# Altium schematic sheet H2O_Board.SchDoc(H2O_Board) (continued)

## Library data of the parts
*1 = CMP-019-00001-1: Three Terminal Voltage Reference, 1 Output, 2.5V, CMOS, PDSO5; Case/Package: SOT-23; Component Kind: Standard; Library Name: CUAUV - Cornell University
C5 C7 C9 C10 C22-C24 C26 C35-C40 C48 = CMP-001-00015-1: Ceramic Capacitor, Multilayer, Ceramic, 16V, 5% +Tol, 5% -Tol, X7R, 15% TC, 0.1uF, Surface Mount, 0603; Capacitor Type: Ceramic; Case/Package: 0603; Component Kind: Standard; Max Operating Temperature: 125°C; Min Operating Temperature: -55°C; Mounting Technology: Surface Mount; Pins: 2; RoHS Compliant: Yes; Library Name: CUAUV - Cornell University
C6 = CMP-001-00021-2: Ceramic Capacitor, Multilayer, Ceramic, 10V, 10% +Tol, 10% -Tol, X5R, 15% TC, 10uF, Surface Mount, 0603; Capacitor Type: Ceramic; Case/Package: 0603; Component Kind: Standard; Max Operating Temperature: 85°C; Min Operating Temperature: -55°C; Mounting Technology: Surface Mount; Pins: 2; RoHS Compliant: Yes; Library Name: CUAUV - Cornell University
C8 C31 C46 C50 C54 = CMP-001-00013-2: Ceramic Capacitor, Multilayer, Ceramic, 16V, 80% +Tol, 20% -Tol, Y5V, -82/+22% TC, 1uF, Surface Mount, 0603; Capacitor Type: Ceramic; Case/Package: 0603; Component Kind: Standard; Max Operating Temperature: 85°C; Min Operating Temperature: -30°C; Mounting Technology: Surface Mount; Pins: 2; RoHS Compliant: Yes; Library Name: CUAUV - Cornell University
C11 C12 C15 C16 = CMP-001-00021-1: Ceramic Capacitor, Multilayer, Ceramic, 10V, 10% +Tol, 10% -Tol, X5R, 15% TC, 10uF, Surface Mount, 0603; Capacitor Type: Ceramic; Case/Package: 0603; Component Kind: Standard; Max Operating Temperature: 85°C; Min Operating Temperature: -55°C; Mounting Technology: Surface Mount; Pins: 2; RoHS Compliant: Yes; Library Name: CUAUV - Cornell University
C13 C14 C45 C47 C51 C52 = CMP-001-00017-1: Ceramic Capacitor, Multilayer, Ceramic, 16V, 5% +Tol, 5% -Tol, X7R, 15% TC, 0.1uF, Surface Mount, 0603; Capacitor Type: Ceramic; Case/Package: 0603; Component Kind: Standard; Max Operating Temperature: 125°C; Min Operating Temperature: -55°C; Mounting Technology: Surface Mount; Pins: 2; RoHS Compliant: Yes; Library Name: CUAUV - Cornell University
C17 C27 C33 C34 C42 = CMP-001-00018-1: Ceramic Capacitor, Multilayer, Ceramic, 10V, 10% +Tol, 10% -Tol, X7R, 15% TC, 2.2uF, Surface Mount, 0603; Capacitor Type: Ceramic; Case/Package: 0603; Component Kind: Standard; Max Operating Temperature: 125°C; Min Operating Temperature: -55°C; Mounting Technology: Surface Mount; Pins: 2; RoHS Compliant: Yes; Library Name: CUAUV - Cornell University
C18 = CMP-001-00011-3: Tantalum Capacitor, Polarized, Tantalum (dry/solid), 10V, 20% +Tol, 20% -Tol, 10uF, Surface Mount, 0805; Capacitor Type: Tantalum; Case/Package: 0805; Component Kind: Standard; Max Operating Temperature: 125°C; Min Operating Temperature: -55°C; Mounting Technology: Surface Mount; Pins: 2; RoHS Compliant: Yes; Library Name: CUAUV - Cornell University
C19 = CMP-001-00022-1: Ceramic Capacitor, Multilayer, Ceramic, 50V, 10% +Tol, 10% -Tol, X7R, 15% TC, 0.01uF, Surface Mount, 0603; Capacitor Type: Ceramic; Case/Package: 0603; Component Kind: Standard; Max Operating Temperature: 125°C; Min Operating Temperature: -55°C; Mounting Technology: Surface Mount; Pins: 2; RoHS Compliant: Yes; Library Name: CUAUV - Cornell University
C20 = CMP-001-00014-1: Ceramic Capacitor, Multilayer, Ceramic, 35V, 10% +Tol, 10% -Tol, X5R, 15% TC, 4.7uF, Surface Mount, 0603; Capacitor Type: Ceramic; Case/Package: 0603; Component Kind: Standard; Max Operating Temperature: 85°C; Min Operating Temperature: -55°C; Mounting Technology: Surface Mount; Pins: 2; RoHS Compliant: Yes; Library Name: CUAUV - Cornell University
C21 = CMP-001-00039-1: Ceramic Capacitor, Multilayer, Ceramic, 6.3V, 20% +Tol, 20% -Tol, X5R, 15% TC, 22uF, Surface Mount, 0603; Capacitor Type: Ceramic; Case/Package: 0603; Component Kind: Standard; Max Operating Temperature: 85°C; Min Operating Temperature: -55°C; Mounting Technology: Surface Mount; Pins: 2; RoHS Compliant: Yes; Library Name: CUAUV - Cornell University
C30 C43 C49 C53 = CMP-001-00012-2: SMD Comm X8R HT150C 0603 (1608Metric) ceramic capacitor 0.063Lx0.031W 1.60mmx0.80mm X8R ±5% 300pF; Component Kind: Standard; Library Name: CUAUV - Cornell University
C32 C44 = CMP-001-00016-1: Ceramic Capacitor, Multilayer, Ceramic, 50V, 5% +Tol, 5% -Tol, C0G, 30ppm/Cel TC, 0.00001uF, Surface Mount, 0402; Capacitor Type: Ceramic; Case/Package: 0402; Component Kind: Standard; Max Operating Temperature: 125°C; Min Operating Temperature: -55°C; Mounting Technology: Surface Mount; Pins: 2; RoHS Compliant: Yes; Library Name: CUAUV - Cornell University
C41 = CMP-001-00015-1: Ceramic Capacitor, Multilayer, Ceramic, 16V, 5% +Tol, 5% -Tol, X7R, 15% TC, 0.1uF, Surface Mount, 0603; Value: 100nF; Capacitor Type: Ceramic; Case/Package: 0603; Component Kind: Standard; Max Operating Temperature: 125°C; Min Operating Temperature: -55°C; Mounting Technology: Surface Mount; Pins: 2; RoHS Compliant: Yes; Library Name: CUAUV - Cornell University
D1 D2 = CMP-007-00000-2: Single Color LED, Blue, Water Clear, 1.4mm; Forward Current: 30mA; Component Kind: Standard; Max Operating Temperature: 85°C; Min Operating Temperature: -40°C; Mounting Technology: Surface Mount; Pins: 2; RoHS Compliant: Yes; Library Name: CUAUV - Cornell University
D3 = CMP-007-00003-1: Single Color LED, Green, Water Clear, 1.4mm; Forward Current: 30mA; Component Kind: Standard; Max Operating Temperature: 85°C; Min Operating Temperature: -40°C; Mounting Technology: Surface Mount; Pins: 2; RoHS Compliant: Yes; Library Name: CUAUV - Cornell University
D4 D7-D10 = CMP-007-00001-1: Single Color LED, Red, Water Clear, 1.4mm; Forward Current: 30mA; Component Kind: Standard; Max Operating Temperature: 85°C; Min Operating Temperature: -40°C; Mounting Technology: Surface Mount; Pins: 2; RoHS Compliant: Yes; Library Name: CUAUV - Cornell University
D5 = CMP-007-00002-1: Single Color LED, Yellow, Water Clear, 1.4mm; Forward Current: 20mA; Forward Voltage: 2.6V; Component Kind: Standard; Max Operating Temperature: 85°C; Min Operating Temperature: -55°C; Mounting Technology: Surface Mount; Pins: 2; RoHS Compliant: Yes; Library Name: CUAUV - Cornell University
D6 = CMP-004-00002-1: Rectifier Diode, Schottky, 1 Phase, 1 Element, 2A, 30V V(RRM), Silicon; Forward Voltage: 500mV; Component Kind: Standard; Max Operating Temperature: 150°C; Min Operating Temperature: -65°C; Pins: 2; RoHS Compliant: Yes; Library Name: CUAUV - Cornell University
J2 = CMP-002-00007-1: Board Connector, 3 Contact(s), 1 Row(s), Male, Straight, 0.079 inch Pitch, Surface Mount Terminal, Locking, Beige Insulator, Receptacle; Component Kind: Standard; Connector Type: BOARD CONNECTOR; Max Operating Temperature: 85°C; Min Operating Temperature: -25°C; Mounting Technology: Board; RoHS Compliant: Yes; Library Name: CUAUV - Cornell University
J3 = CMP-002-00005-1: Rectangular Power Connector, 2 Contact(s), Male, Solder Terminal, Receptacle; Connector Type: Power,Rectangular; Component Kind: Standard; Max Operating Temperature: 105°C; Min Operating Temperature: -40°C; Mounting Technology: Board; RoHS Compliant: Yes; Library Name: CUAUV - Cornell University
J4 J6 J8 J10 J12-J15 J18-J30 J32-J35 J37-J39 = CMP-00030-00000-1: Team common testpoint; Component Kind: Standard; RoHS Compliant: Yes; Library Name: CUAUV - Cornell University
J5 J7 J9 J11 = CMP-002-00000-1: RF SMA Connector, 1 Contact(s), Female, Board Mount, Solder Terminal, Locking, Socket; Component Kind: Standard; Connector Type: SMA; Max Operating Temperature: 85°C; Min Operating Temperature: -55°C; Mounting Technology: Board; RoHS Compliant: Yes; Library Name: CUAUV - Cornell University
L1 = CMP-006-00002-1: General Purpose Inductor, 4.7uH, 20%, 1 Element, Composite-Core, SMD, 2525; Max Current Rating: 5.5A; Component Kind: Standard; Max Operating Temperature: 125°C; Min Operating Temperature: -55°C; Pins: 2; RoHS Compliant: Yes; Tolerance: 20%; Library Name: CUAUV - Cornell University
L2 L3 = CMP-006-00001-1: General Purpose Inductor, 33uH, 20%, 1 Element, Ferrite-Core, SMD, 1212; Max Current Rating: 500mA; Self Resonant Frequency: 22MHz; Component Kind: Standard; Max Operating Temperature: 125°C; Min Operating Temperature: -40°C; Pins: 2; RoHS Compliant: Yes; Tolerance: 20%; Library Name: CUAUV - Cornell University
P2 = CMP-002-00004-1: Board Connector, 6 Contact(s), 2 Row(s), Male, Straight, 0.1 inch Pitch, Solder Terminal, Black Insulator, Plug; Component Kind: Standard; Connector Type: BOARD CONNECTOR; Max Operating Temperature: 125°C; Min Operating Temperature: -40°C; Mounting Technology: Board; RoHS Compliant: Yes; Library Name: CUAUV - Cornell University
PS1 = CMP-095-000001-1: Isolated Module DC DC Converter 1 Output 5V - - 600mA 9V - 18V Input; MF: TDK-Lambda Americas Inc.; Availability: In Stock; Check_prices: https://www.snapeda.com/parts/CC3-1205SF-E/TDK-Lambda+Americas+Inc./view-part/?ref=eda; Component Kind: Standard; Component Type: Standard; Design Item ID: CC3-1205SF-E; MP: CC3-1205SF-E; Package: DIP-11 TDK-Lambda; Pin Count: 9; Price: None; Purchase-URL: https://pricing.snapeda.com/search/part/CC3-1205SF-E/?ref=eda; SnapEDA_Link: https://www.snapeda.com/parts/CC3-1205SF-E/TDK-Lambda+Americas+Inc./view-part/?ref=snap; Source: CC3-1205SF-E.SchLib; Library Name: CUAUV - Cornell University
R8 = CMP-009-00063-1: Fixed Resistor, Metal Glaze/thick Film, 0.1W, 31600ohm, 75V, 1% +/-Tol, 100ppm/Cel, Surface Mount, 0603; Power: 100mW; Case/Package: 0603; Component Kind: Standard; Max Operating Temperature: 155°C; Min Operating Temperature: -55°C; Mounting Technology: Surface Mount; Pins: 2; RoHS Compliant: Yes; Tolerance: 1%; Library Name: CUAUV - Cornell University
R9-R14 = CMP-009-00008-1: Fixed Resistor, Metal Glaze/thick Film, 0.1W, 47000ohm, 75V, 1% +/-Tol, 100ppm/Cel, Surface Mount, 0603; Power: 100mW; Case/Package: 0603; Component Kind: Standard; Max Operating Temperature: 155°C; Min Operating Temperature: -55°C; Mounting Technology: Surface Mount; Pins: 2; RoHS Compliant: Yes; Tolerance: 1%; Library Name: CUAUV - Cornell University
R15 = CMP-009-00014-1: Fixed Resistor, Metal Glaze/thick Film, 0.1W, 18ohm, 75V, 1% +/-Tol, 100ppm/Cel, Surface Mount, 0603; Power: 100mW; Case/Package: 0603; Component Kind: Standard; Max Operating Temperature: 155°C; Min Operating Temperature: -55°C; Mounting Technology: Surface Mount; Pins: 2; RoHS Compliant: Yes; Tolerance: 1%; Library Name: CUAUV - Cornell University
R16 = CMP-009-00062-1: Fixed Resistor, Metal Glaze/thick Film, 0.1W, 10200ohm, 75V, 1% +/-Tol, 100ppm/Cel, Surface Mount, 0603; Power: 100mW; Case/Package: 0603; Component Kind: Standard; Max Operating Temperature: 155°C; Min Operating Temperature: -55°C; Mounting Technology: Surface Mount; Pins: 2; RoHS Compliant: Yes; Tolerance: 1%; Library Name: CUAUV - Cornell University
R17-R19 R21 R22 R25 R26 R28 R35 = CMP-009-00005-1: Metal Glaze/thick Film, 0.125W, 0ohm, Surface Mount, 0805; Power: 125mW; Case/Package: 0805; Component Kind: Standard; Max Operating Temperature: 155°C; Min Operating Temperature: -55°C; Mounting Technology: Surface Mount; Pins: 2; RoHS Compliant: Yes; Library Name: CUAUV - Cornell University
R20 R24 R27 R29 = CMP-009-00006-2: Fixed Resistor, Metal Glaze/thick Film, 0.1W, 100000ohm, 75V, 5% +/-Tol, 200ppm/Cel, Surface Mount, 0603; Power: 100mW; Case/Package: 0603; Component Kind: Standard; Max Operating Temperature: 155°C; Min Operating Temperature: -55°C; Mounting Technology: Surface Mount; Pins: 2; RoHS Compliant: Yes; Tolerance: 5%; Library Name: CUAUV - Cornell University
R23 = CMP-009-00011-1: Fixed Resistor, Metal Glaze/thick Film, 0.1W, 5100ohm, 75V, 1% +/-Tol, 100ppm/Cel, Surface Mount, 0603; Power: 100mW; Case/Package: 0603; Component Kind: Standard; Max Operating Temperature: 155°C; Min Operating Temperature: -55°C; Mounting Technology: Surface Mount; Pins: 2; RoHS Compliant: Yes; Tolerance: 1%; Library Name: CUAUV - Cornell University
R30-R34 R153 R155 = CMP-009-00009-1: Fixed Resistor, Metal Glaze/thick Film, 0.1W, 330ohm, 75V, 1% +/-Tol, 100ppm/Cel, Surface Mount, 0603; Power: 100mW; Case/Package: 0603; Component Kind: Standard; Max Operating Temperature: 155°C; Min Operating Temperature: -55°C; Mounting Technology: Surface Mount; Pins: 2; RoHS Compliant: Yes; Tolerance: 1%; Library Name: CUAUV - Cornell University
R152 = CMP-009-00015-1: Fixed Resistor, Thin Film, 0.125W, 2150ohm, 100V, 0.1% +/-Tol, 25ppm/Cel, Surface Mount, 0805; Power: 125mW; Case/Package: 0805; Component Kind: Standard; Max Operating Temperature: 155°C; Min Operating Temperature: -55°C; Mounting Technology: Surface Mount; Pins: 2; RoHS Compliant: Yes; Tolerance: 0.1%; Library Name: CUAUV - Cornell University
R154 = CMP-009-00047-1: Fixed Resistor, Thin Film, 0.1W, 1000ohm, 75V, 0.1% +/-Tol, 25ppm/Cel, Surface Mount, 0603; Power: 100mW; Case/Package: 0603; Component Kind: Standard; Max Operating Temperature: 155°C; Min Operating Temperature: -55°C; Mounting Technology: Surface Mount; Pins: 2; RoHS Compliant: Yes; Tolerance: 0.1%; Library Name: CUAUV - Cornell University
SD1 = CMP-002-00003-1: PCB Connector, 8 Contact(s), 1 Row(s), Male, Right Angle, 0.043 inch Pitch, Surface Mount Terminal, Black Insulator, Socket; Component Kind: Standard; Connector Type: PCB; Max Operating Temperature: 85°C; Min Operating Temperature: -20°C; Mounting Technology: Board; RoHS Compliant: Yes; Library Name: CUAUV - Cornell University
SW1 = CMP-011-00000-5: Tactile Switch SPST-NO Top Actuated Surface Mount; Mfr_Name: E-Switch; Component Kind: Standard; Component Type: Standard; Design Item ID: TL3302AF130QJ; Mounting Technology: Surface Mount; Pins: 2; RoHS Compliant: Yes; Source: TL3302AF130QJ.SchLib; Library Name: CUAUV - Cornell University
U2 = CMP-019-00005-1: Switching Regulator, Current-mode, 2.5A, 900kHz Switching Freq-Max, PDSO6; Max Supply Voltage: 20V; Case/Package: VSSOP; Component Kind: Standard; Frequency: 900kHz; Max Operating Temperature: 125°C; Min Operating Temperature: -40°C; Pins: 6; RoHS Compliant: Yes; Library Name: CUAUV - Cornell University
U3 = CMP-015-00000-1: Line Transceiver, 3 Func, 3 Driver, 3 Rcvr, CMOS, PDSO24; Max Supply Voltage: 3.6V; Min Supply Voltage: 2.7V; Nominal Supply Voltage: 3.3V; Case/Package: TSSOP; Component Kind: Standard; Max Operating Temperature: 85°C; Min Operating Temperature: -40°C; Pins: 24; RoHS Compliant: Yes; Library Name: CUAUV - Cornell University
U4 = CMP-019-00002-1: Fixed Positive LDO Regulator, 5V, 0.3V Dropout, BICMOS, PDSO6; Max Supply Voltage: 5.5V; Case/Package: SOP; Component Kind: Standard; Pins: 6; RoHS Compliant: Yes; Library Name: CUAUV - Cornell University
U5 = CMP-020-00000-1: RISC Microcontroller, 32-Bit, FLASH, 400MHz, CMOS, PQFP100; Component Kind: Standard; RoHS Compliant: Yes; Library Name: CUAUV - Cornell University
U6 U7 = CMP-015-00002-1: Interface Circuit, CMOS, PDSO16; Component Kind: Standard; RoHS Compliant: Yes; Library Name: CUAUV - Cornell University
Y1 = CMP-003-00000-1: Crystal 25MHz ±30ppm (Tol) ±30ppm (Stability) 10pF FUND 60Ohm 4-Pin Ultra Mini-CSMD T/R; Component Kind: Standard; Library Name: CUAUV - Cornell University
